# Altium schematic sheet TouchSensor.SchDoc(TouchSensor)
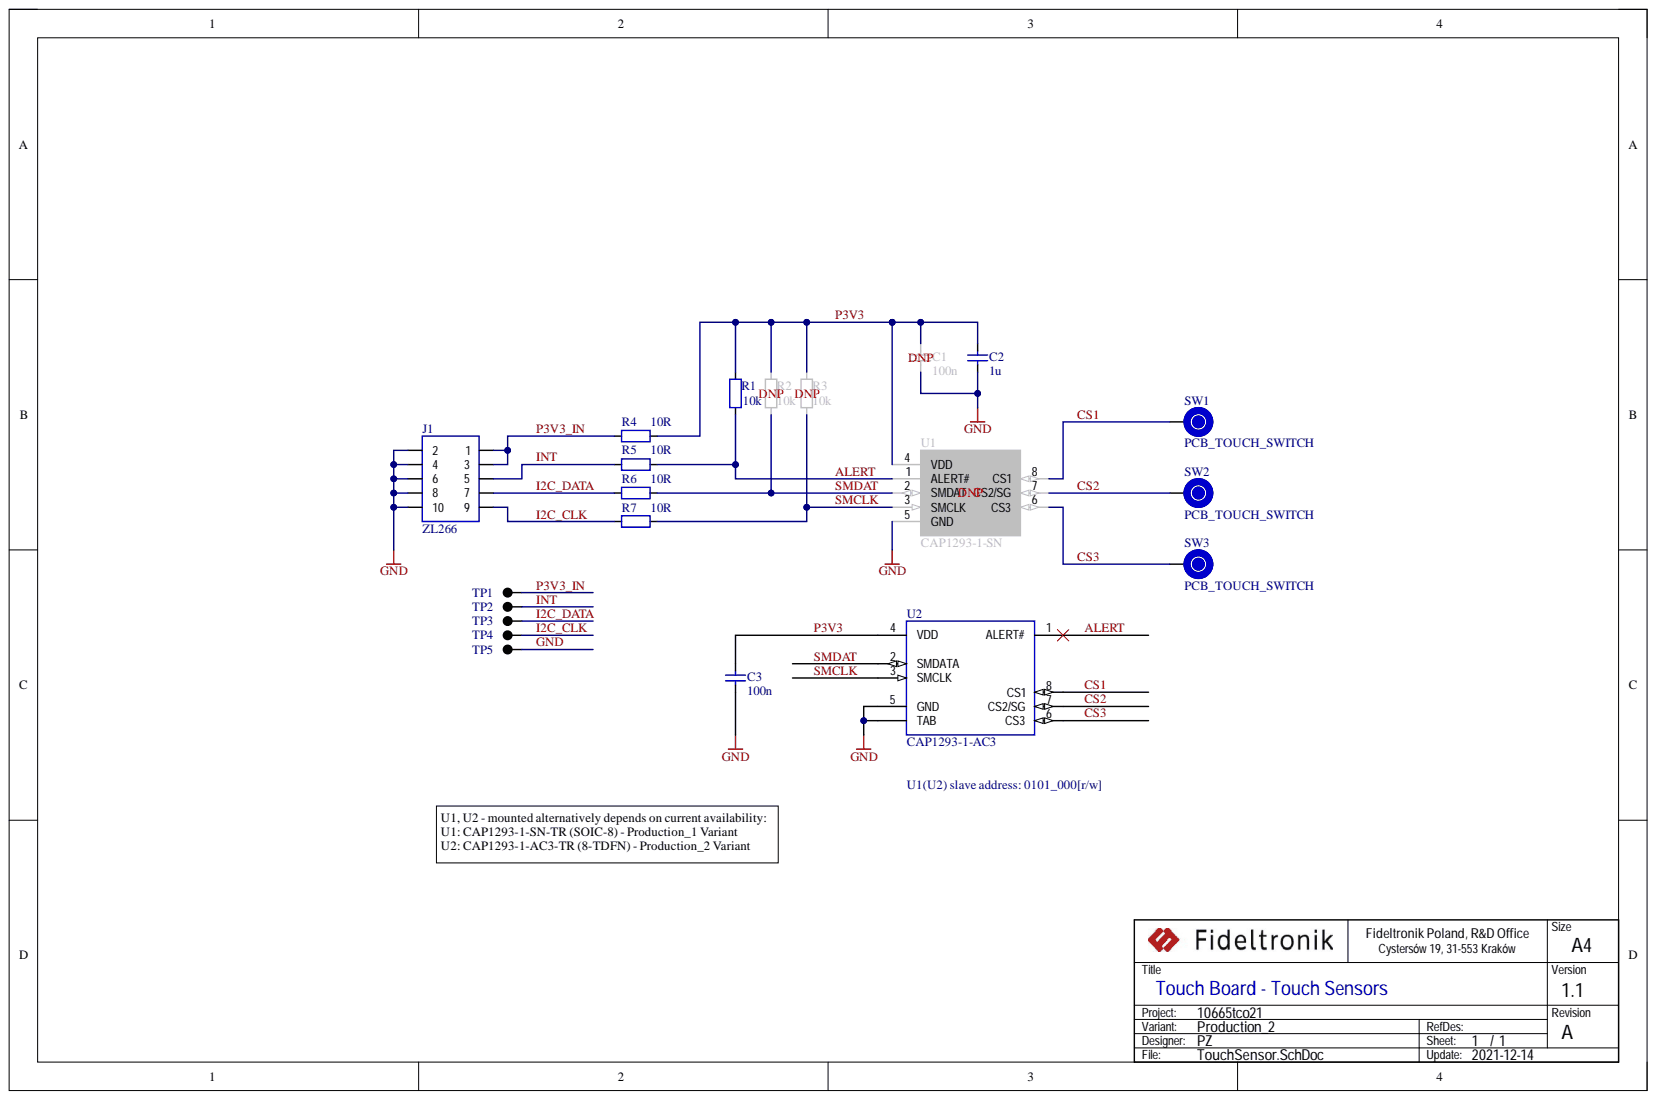
[diagram p0: TouchSensor.SchDoc]

## Parts
C1  100n  [CAP_0603_R]
C2  1u  [CAP_0603_R]
C3  100n  [CAP_0603_R]
J1  ZL266  [CON_NGI_ZL266-10DG_R]
R1  10k  [RES_0603_R]
R2  10k  [RES_0603_R]
R3  10k  [RES_0603_R]
R4  10R  [RES_0603_R]
R5  10R  [RES_0603_R]
R6  10R  [RES_0603_R]
R7  10R  [RES_0603_R]
SW1  PCB_TOUCH_SWITCH  [PCB_TOUCH_SWITCH_PLATE]  (no BOM)
SW2  PCB_TOUCH_SWITCH  [PCB_TOUCH_SWITCH_PLATE]  (no BOM)
SW3  PCB_TOUCH_SWITCH  [PCB_TOUCH_SWITCH_PLATE]  (no BOM)
TP1  PCB_TP_ICT  [PCB_TP_ICT_100]  (value not drawn)  (no BOM)
TP2  PCB_TP_ICT  [PCB_TP_ICT_100]  (value not drawn)  (no BOM)
TP3  PCB_TP_ICT  [PCB_TP_ICT_100]  (value not drawn)  (no BOM)
TP4  PCB_TP_ICT  [PCB_TP_ICT_100]  (value not drawn)  (no BOM)
TP5  PCB_TP_ICT  [PCB_TP_ICT_100]  (value not drawn)  (no BOM)
U1  CAP1293-1-SN  [PKG_SOP08_R]
U2  CAP1293-1-AC3  [PKG_DFN8_L200W300H100P50_R]

## Nets
ALERT: R1.1 R5.1 U1.1(ALERT#) U2.1(ALERT#)
CS1: SW1.1 U1.8(CS1) U2.8(CS1)
CS2: SW2.1 U1.7(CS2/SG) U2.7(CS2/SG)
CS3: SW3.1 U1.6(CS3) U2.6(CS3)
GND: C1.1 C2.1 C3.1 J1.2 J1.4 J1.6 J1.8 J1.10 TP5.1 U1.5(GND) U2.5(GND) U2.TAB
I2C_CLK: J1.9 R7.2 TP4.1
I2C_DATA: J1.7 R6.2 TP3.1
INT: J1.5 R5.2 TP2.1
P3V3: C1.2 C2.2 C3.2 R1.2 R2.2 R3.2 R4.1 U1.4(VDD) U2.4(VDD)
P3V3_IN: J1.1 J1.3 R4.2 TP1.1
SMCLK: R3.1 R7.1 U1.3(SMCLK) U2.3(SMCLK)
SMDAT: R2.1 R6.1 U1.2(SMDAT) U2.2(SMDATA)

## Library data of the parts
C1 C3 = FT_C00015: CAP SMD 100n 10% 50V X7R (0603); Manufacturer: AVX; Manufacturer Part Number: 06035C104KAT2A; Database Table Name: CAPACITOR$; EBS: M1FT10130; SCH SYMBOL STATUS: Checked; Capacitance: 100n; Case: 0603; Component Kind: Standard; Dielectric: X7R; ITEM: FT_C00015; Library Name: FT_DB_LOCAL.DbLib; Mounting: S; STATUS: 2; Tolerance: 10%; Voltage: 50V
C2 = FT_C00220: CAP SMD 1u 10% 16V X7R (0603); Manufacturer: AVX; Manufacturer Part Number: 0603YC105KAT2A; Database Table Name: CAPACITOR$; EBS: M1FT12210; SCH SYMBOL STATUS: Checked; Capacitance: 1u; Case: 0603; Component Kind: Standard; Dielectric: X7R; ITEM: FT_C00220; Library Name: FT_DB_LOCAL.DbLib; Mounting: S; STATUS: 2; Tolerance: 10%; Voltage: 16V
J1 = FT_J00171: CONN SMD / BOARD TO BOARD / PITCH 2mm / Vertical / Double Row (2x5 PIN); Manufacturer: NINIGI; Manufacturer Part Number: ZL266-10DG; Database Table Name: CONNECTOR$; Pitch (mm): 2; SCH SYMBOL STATUS: Checked; Case: 10x4x4; Component Kind: Standard; ITEM: FT_J00171; Library Name: FT_DB_LOCAL.DbLib; Mounting: S; Orientation: Vertical; Pins: 2x5; Rows: Double Row; STATUS: 0; Type: BOARD TO BOARD
R1-R3 = FT_R00098: RES SMD 10k 1% 0.1W 100PPM (0603); Manufacturer: ASJ; Manufacturer Part Number: CR16-1002-FL; Database Table Name: RESISTOR$; EBS: M1FT00970; SCH SYMBOL STATUS: Checked; Case: 0603; Component Kind: Standard; ITEM: FT_R00098; Library Name: FT_DB_LOCAL.DbLib; Mounting: S; Power: 0.1W; PPM: 100PPM; Resistance: 10k; STATUS: 2; Tolerance: 1%
R4-R7 = FT_R00225: RES SMD 10R 1% 0.1W 100PPM (0603); Manufacturer: ASJ; Manufacturer Part Number: CR16-10R0-FL; Database Table Name: RESISTOR$; EBS: M1FT02240; SCH SYMBOL STATUS: Checked; Case: 0603; Component Kind: Standard; ITEM: FT_R00225; Library Name: FT_DB_LOCAL.DbLib; Mounting: S; Power: 0.1W; PPM: 100PPM; Resistance: 10R; STATUS: 2; Tolerance: 1%
SW1-SW3 = PCB_TOUCH_SWITCH: SCH SYMBOL STATUS: Checked; Library Name: FT_Symbols_NO_BOM.SchLib
TP1-TP5 = PCB_TP_ICT: SCH SYMBOL STATUS: Checked; Library Name: FT_Symbols_NO_BOM.SchLib
U1 = FT_U00241: IC SMD / TOUCH SENSOR CAP / 3 CHANELLS I2C (SOIC-8); Manufacturer: MICROCHIP; Manufacturer Part Number: CAP1293-1-SN-TR; Database Table Name: IC$; SCH SYMBOL STATUS: New; Case: SOIC-8; Component Kind: Standard; ITEM: FT_U00241; Library Name: FT_DB_LOCAL.DbLib; Mounting: S; Properties: 3 CHANELLS I2C; STATUS: 0; Type: TOUCH SENSOR CAP
U2 = FT_U00260: IC SMD / TOUCH SENSOR CAP / 3 CHANELLS I2C (8-TDFN); Manufacturer: MICROCHIP; Manufacturer Part Number: CAP1293-1-AC3-TR; Database Table Name: IC$; SCH SYMBOL STATUS: New; Case: 8-TDFN; Component Kind: Standard; ITEM: FT_U00260; Library Name: FT_DB_LOCAL.DbLib; Mounting: S; Properties: 3 CHANELLS I2C; STATUS: 0; Type: TOUCH SENSOR CAP
